# Altium schematic sheet ControlBoard.SchDoc
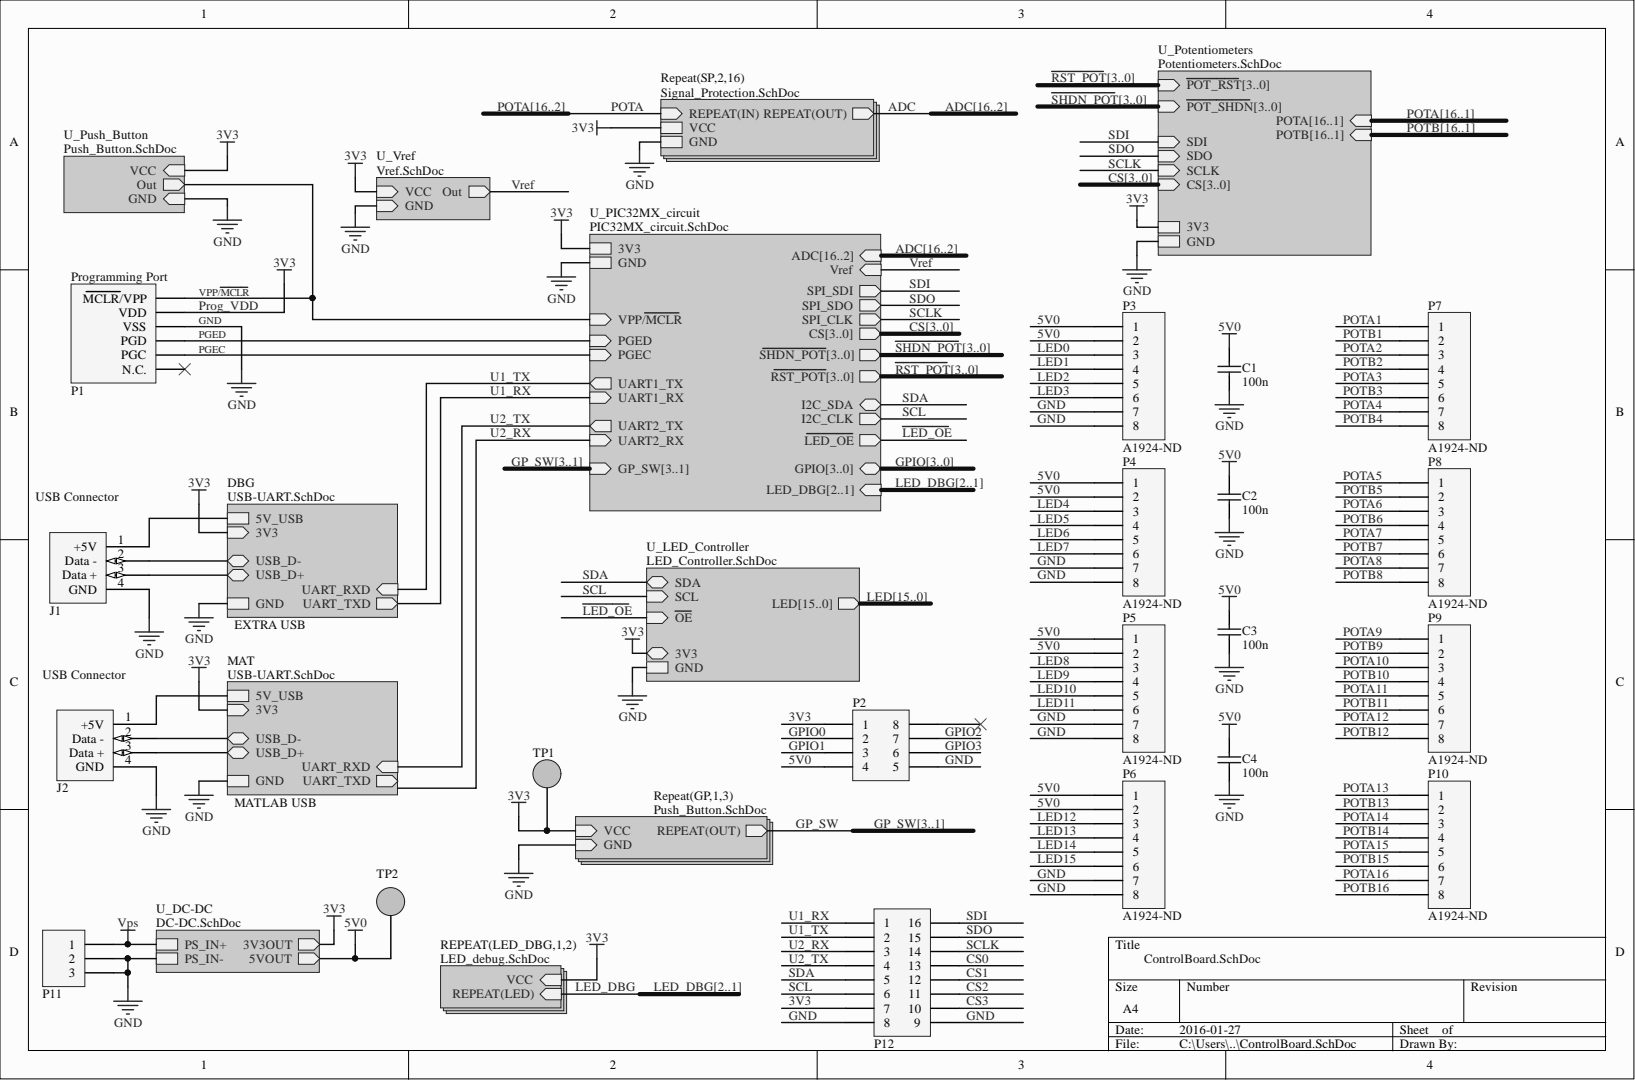
[diagram p0: ControlBoard.SchDoc]

## Parts
C1  value 100n  [CS-0603]  (hidden comment: CS - CAPACITOR)
C2  value 100n  [CS-0603]  (hidden comment: CS - CAPACITOR)
C3  value 100n  [CS-0603]  (hidden comment: CS - CAPACITOR)
C4  value 100n  [CS-0603]  (hidden comment: CS - CAPACITOR)
J1  USB 4 pins  [CON-USB TYPE B]  (value not drawn)
J2  USB 4 pins  [CON-USB TYPE B]  (value not drawn)
P1  CON - HEADER PROG  [CON-HEADER-1X6-RA]  (value not drawn)
P2  CON - HEADER 2X4  [CON-HEADER-2X4]  (value not drawn)
P3  Supplier Part Number 1: A1924-ND  [CON-HEADER-1X8]  (hidden comment: CON - HEADER 1X8)
P4  Supplier Part Number 1: A1924-ND  [CON-HEADER-1X8]  (hidden comment: CON - HEADER 1X8)
P5  Supplier Part Number 1: A1924-ND  [CON-HEADER-1X8]  (hidden comment: CON - HEADER 1X8)
P6  Supplier Part Number 1: A1924-ND  [CON-HEADER-1X8]  (hidden comment: CON - HEADER 1X8)
P7  Supplier Part Number 1: A1924-ND  [CON-HEADER-1X8]  (hidden comment: CON - HEADER 1X8)
P8  Supplier Part Number 1: A1924-ND  [CON-HEADER-1X8]  (hidden comment: CON - HEADER 1X8)
P9  Supplier Part Number 1: A1924-ND  [CON-HEADER-1X8]  (hidden comment: CON - HEADER 1X8)
P10  Supplier Part Number 1: A1924-ND  [CON-HEADER-1X8]  (hidden comment: CON - HEADER 1X8)
P11  CON - POWER CONNECTOR  [CON-POWER CONNECTOR]  (value not drawn)
P12  CON - HEADER 2X8  [CON-HEADER-2X8]  (value not drawn)
TP1  5003K  [TP - VERTICAL]  (value not drawn)
TP2  5003K  [TP - VERTICAL]  (value not drawn)

## Nets
VPS: P11.1
3V3: P1.2(VDD) P2.1 P12.7 TP1.1
5V0: C1.1 C2.1 C3.1 C4.1 P2.4 P3.1 P3.2 P4.1 P4.2 P5.1 P5.2 P6.1 P6.2 TP2.1
CS0: P12.13  [also labelled CS]
CS1: P12.12  [also labelled CS]
CS2: P12.11  [also labelled CS]
CS3: P12.10  [also labelled CS]
GND: C1.2 C2.2 C3.2 C4.2 J1.4(GND) J1.5 J1.6 J2.4(GND) J2.5 J2.6 P1.3(VSS) P2.5 P3.7 P3.8 P4.7 P4.8 P5.7 P5.8 P6.7 P6.8 P11.2 P11.3 P12.8 P12.9
GPIO0: P2.2  [also labelled GPIO]
GPIO1: P2.3  [also labelled GPIO]
GPIO2: P2.7  [also labelled GPIO]
GPIO3: P2.6  [also labelled GPIO]
LED0: P3.3  [also labelled LED]
LED1: P3.4  [also labelled LED]
LED2: P3.5  [also labelled LED]
LED3: P3.6  [also labelled LED]
LED4: P4.3  [also labelled LED]
LED5: P4.4  [also labelled LED]
LED6: P4.5  [also labelled LED]
LED7: P4.6  [also labelled LED]
LED8: P5.3  [also labelled LED]
LED9: P5.4  [also labelled LED]
LED10: P5.5  [also labelled LED]
LED11: P5.6  [also labelled LED]
LED12: P6.3  [also labelled LED]
LED13: P6.4  [also labelled LED]
LED14: P6.5  [also labelled LED]
LED15: P6.6  [also labelled LED]
PGEC: P1.5(PGC)
PGED: P1.4(PGD)
POTA1: P7.1  [also labelled POTA]
POTA2: P7.3  [also labelled POTA]
POTA3: P7.5  [also labelled POTA]
POTA4: P7.7  [also labelled POTA]
POTA5: P8.1  [also labelled POTA]
POTA6: P8.3  [also labelled POTA]
POTA7: P8.5  [also labelled POTA]
POTA8: P8.7  [also labelled POTA]
POTA9: P9.1  [also labelled POTA]
POTA10: P9.3  [also labelled POTA]
POTA11: P9.5  [also labelled POTA]
POTA12: P9.7  [also labelled POTA]
POTA13: P10.1  [also labelled POTA]
POTA14: P10.3  [also labelled POTA]
POTA15: P10.5  [also labelled POTA]
POTA16: P10.7  [also labelled POTA]
POTB1: P7.2  [also labelled POTB]
POTB2: P7.4  [also labelled POTB]
POTB3: P7.6  [also labelled POTB]
POTB4: P7.8  [also labelled POTB]
POTB5: P8.2  [also labelled POTB]
POTB6: P8.4  [also labelled POTB]
POTB7: P8.6  [also labelled POTB]
POTB8: P8.8  [also labelled POTB]
POTB9: P9.2  [also labelled POTB]
POTB10: P9.4  [also labelled POTB]
POTB11: P9.6  [also labelled POTB]
POTB12: P9.8  [also labelled POTB]
POTB13: P10.2  [also labelled POTB]
POTB14: P10.4  [also labelled POTB]
POTB15: P10.6  [also labelled POTB]
POTB16: P10.8  [also labelled POTB]
SCL: P12.6
SCLK: P12.14
SDA: P12.5
SDI: P12.16
SDO: P12.15
U1_RX: P12.1
U1_TX: P12.2
U2_RX: P12.3
U2_TX: P12.4
VPP/~{MCLR}: P1.1(MCLR/VPP)
NetJ1_1: J1.1(+5V)
NetJ1_2: J1.2(Data_-)
NetJ1_3: J1.3(Data_+)
NetJ2_1: J2.1(+5V)
NetJ2_2: J2.2(Data_-)
NetJ2_3: J2.3(Data_+)
NetP1_6: P1.6(N.C.)
NetP2_8: P2.8
ADC2:  [also labelled ADC]
ADC3:  [also labelled ADC]
ADC4:  [also labelled ADC]
ADC5:  [also labelled ADC]
ADC6:  [also labelled ADC]
ADC7:  [also labelled ADC]
ADC8:  [also labelled ADC]
ADC9:  [also labelled ADC]
ADC10:  [also labelled ADC]
ADC11:  [also labelled ADC]
ADC12:  [also labelled ADC]
ADC13:  [also labelled ADC]
ADC14:  [also labelled ADC]
ADC15:  [also labelled ADC]
ADC16:  [also labelled ADC]
GP_SW1:  [also labelled GP_SW]
GP_SW2:  [also labelled GP_SW]
GP_SW3:  [also labelled GP_SW]
~{LED_OE}:
LED_DBG1:  [also labelled LED_DBG]
LED_DBG2:  [also labelled LED_DBG]
~{RST_POT}0:  [also labelled ~{RST_POT}]
~{RST_POT}1:  [also labelled ~{RST_POT}]
~{RST_POT}2:  [also labelled ~{RST_POT}]
~{RST_POT}3:  [also labelled ~{RST_POT}]
~{SHDN_POT}0:  [also labelled ~{SHDN_POT}]
~{SHDN_POT}1:  [also labelled ~{SHDN_POT}]
~{SHDN_POT}2:  [also labelled ~{SHDN_POT}]
~{SHDN_POT}3:  [also labelled ~{SHDN_POT}]
UART_TXD:
VREF:

## Library data of the parts
C1-C4 = CS - CAPACITOR: TOLERANCE: 10%; VOLTAGE: 16V; Component Kind: Standard; Library Name: CHINOOK_LIBRARY.IntLib
J1 J2 = CON - USB TYPE B 4 pins: 4 pin USB connector with 2 shield pins; Manufacturer: FCI; Component Kind: Standard; Supplier 1: Digi-Key; Supplier Part Number 1: 609-3656-ND; Library Name: CHINOOK_LIBRARY.IntLib
P1 = CON - PROG HEADER 6: MICROCHIP CONNECTOR; Component Kind: Standard; Supplier 1: Digi-Key; Supplier Part Number 1: 609-3263-ND; Library Name: CHINOOK_LIBRARY.IntLib
P2 = CON - HEADER 2X4: 100mil header 2 row 8pins; Component Kind: Standard; Supplier 1: Digi-Key; Supplier Part Number 1: 952-2122-ND; Library Name: CHINOOK_LIBRARY.IntLib
P3-P10 = CON - HEADER 1X8: 100mil header 1 row 6 pins; Component Kind: Standard; Supplier 1: Digi-Key; Library Name: CHINOOK_LIBRARY.IntLib
P11 = CON - POWER CONNECTOR: CONN PWR JACK 2.1X5.5MM HIGH CUR; Component Kind: Standard; Supplier 1: Digi-Key; Supplier Part Number 1: CP-002AH-ND; Library Name: CHINOOK_LIBRARY.IntLib
P12 = CON - HEADER 2X8: 100mil header 2 row 16pins; Component Kind: Standard; Supplier 1: Digi-Key; Supplier Part Number 1: 952-2128-ND; Library Name: CHINOOK_LIBRARY.IntLib
TP1 TP2 = TP - Test point vertical: Component Kind: Standard; Supplier 1: Newark; Supplier Part Number 1: 62W5140; Library Name: CHINOOK_LIBRARY.IntLib
